# Altium schematic sheet bus-main.SchDoc
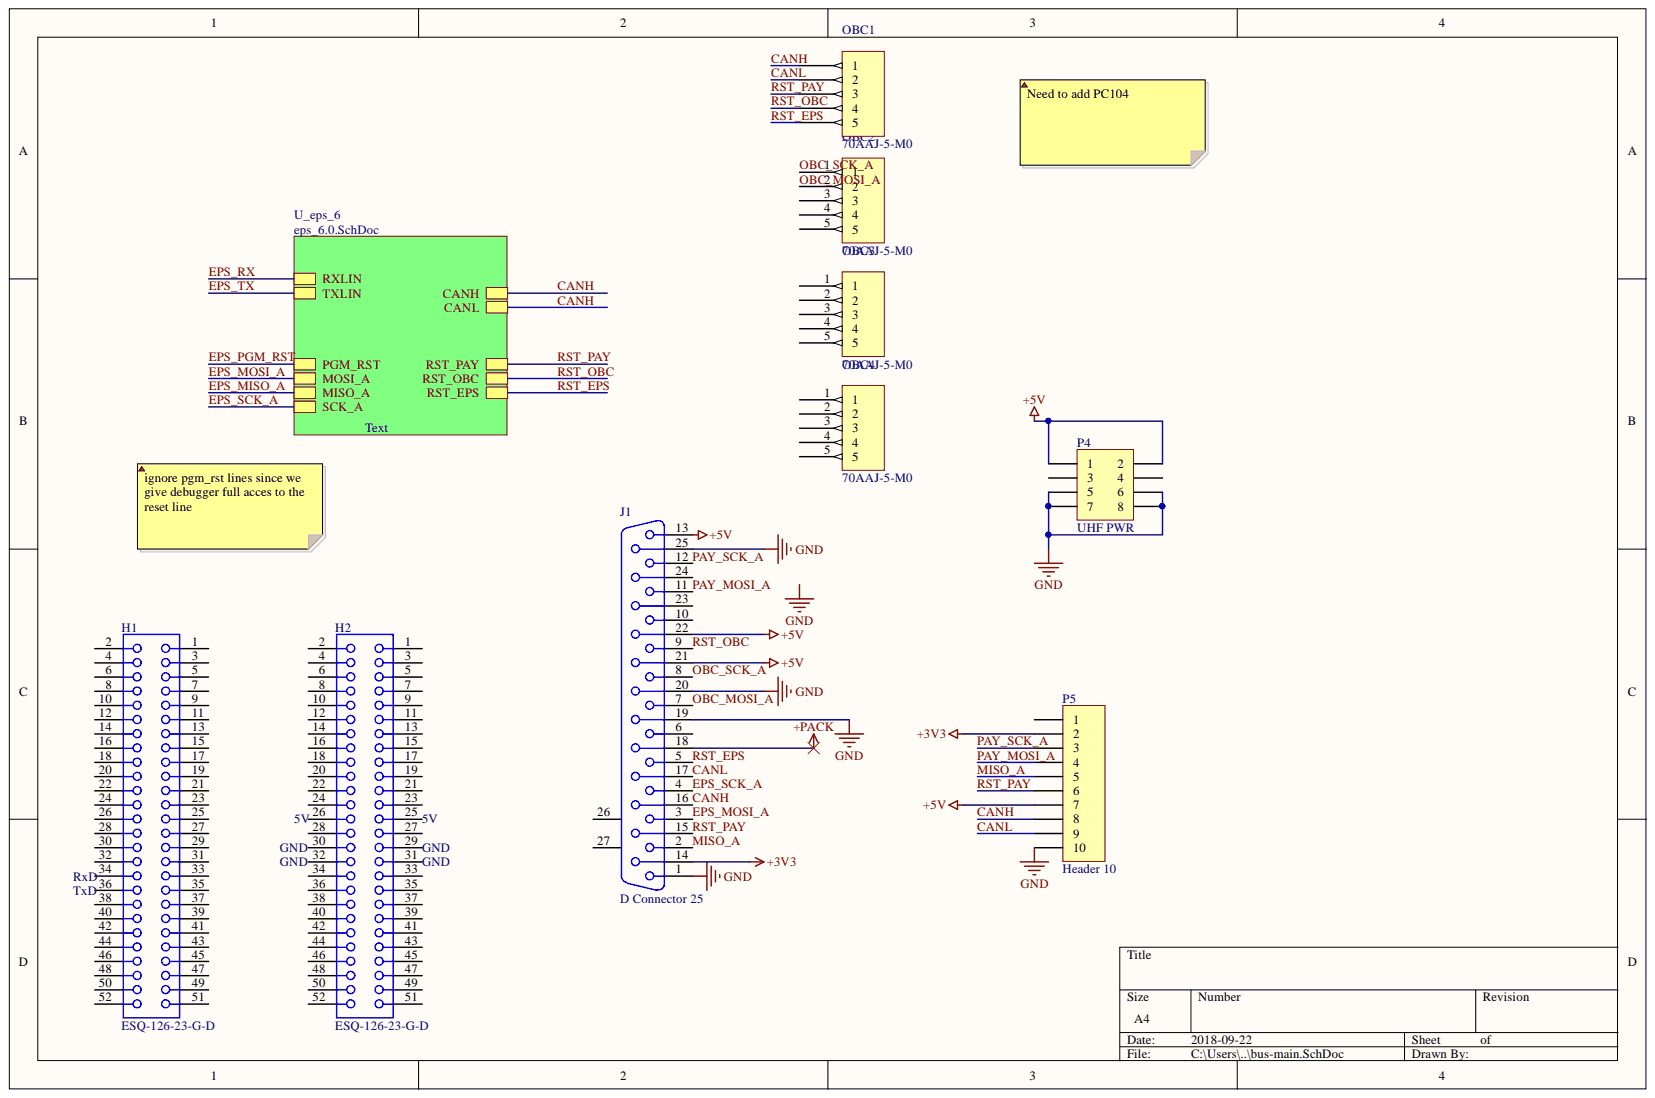
[diagram p0: bus-main.SchDoc]

## Parts
H1  ESQ-126-23-G-D  [SMTC-ESQ-126-23-X-D]
H2  ESQ-126-23-G-D  [SMTC-ESQ-126-23-X-D]
J1  D Connector 25  [Mini DSub Connector]
OBC1  70AAJ-5-M0
OBC2  70AAJ-5-M0
OBC3  70AAJ-5-M0
OBC4  70AAJ-5-M0
P4  UHF PWR  [HDR2X4]
P5  Header 10  [DF14-10P-1.25H(55) - duplicate]

## Nets
+3V3: J1.14 P5.2
+5V: J1.13 J1.21 J1.22 P4.1 P4.2 P5.7
+PACK: J1.18
GND: J1.1 J1.19 J1.20 J1.25 P4.5 P4.6 P4.7 P4.8 P5.10
CANH: J1.16 OBC1.1 P5.8
CANL: J1.17 OBC1.2 P5.9
EPS_MOSI_A: J1.3
EPS_SCK_A: J1.4
MISO_A: J1.2 P5.5
OBC_MOSI_A: J1.7 OBC2.2
OBC_SCK_A: J1.8 OBC2.1
PAY_MOSI_A: J1.11 P5.4
PAY_SCK_A: J1.12 P5.3
RST_EPS: J1.5 OBC1.5
RST_OBC: J1.9 OBC1.4
RST_PAY: J1.15 OBC1.3 P5.6
NetH1_1: H1.1
NetH1_2: H1.2
NetH1_3: H1.3
NetH1_4: H1.4
NetH1_5: H1.5
NetH1_6: H1.6
NetH1_7: H1.7
NetH1_8: H1.8
NetH1_9: H1.9
NetH1_10: H1.10
NetH1_11: H1.11
NetH1_12: H1.12
NetH1_13: H1.13
NetH1_14: H1.14
NetH1_15: H1.15
NetH1_16: H1.16
NetH1_17: H1.17
NetH1_18: H1.18
NetH1_19: H1.19
NetH1_20: H1.20
NetH1_21: H1.21
NetH1_22: H1.22
NetH1_23: H1.23
NetH1_24: H1.24
NetH1_25: H1.25
NetH1_26: H1.26
NetH1_27: H1.27
NetH1_28: H1.28
NetH1_29: H1.29
NetH1_30: H1.30
NetH1_31: H1.31
NetH1_32: H1.32
NetH1_33: H1.33
NetH1_34: H1.34
NetH1_35: H1.35
NetH1_36: H1.36
NetH1_37: H1.37
NetH1_38: H1.38
NetH1_39: H1.39
NetH1_40: H1.40
NetH1_41: H1.41
NetH1_42: H1.42
NetH1_43: H1.43
NetH1_44: H1.44
NetH1_45: H1.45
NetH1_46: H1.46
NetH1_47: H1.47
NetH1_48: H1.48
NetH1_49: H1.49
NetH1_50: H1.50
NetH1_51: H1.51
NetH1_52: H1.52
NetH2_1: H2.1
NetH2_2: H2.2
NetH2_3: H2.3
NetH2_4: H2.4
NetH2_5: H2.5
NetH2_6: H2.6
NetH2_7: H2.7
NetH2_8: H2.8
NetH2_9: H2.9
NetH2_10: H2.10
NetH2_11: H2.11
NetH2_12: H2.12
NetH2_13: H2.13
NetH2_14: H2.14
NetH2_15: H2.15
NetH2_16: H2.16
NetH2_17: H2.17
NetH2_18: H2.18
NetH2_19: H2.19
NetH2_20: H2.20
NetH2_21: H2.21
NetH2_22: H2.22
NetH2_23: H2.23
NetH2_24: H2.24
NetH2_25: H2.25
NetH2_26: H2.26
NetH2_27: H2.27
NetH2_28: H2.28
NetH2_29: H2.29
NetH2_30: H2.30
NetH2_31: H2.31
NetH2_32: H2.32
NetH2_33: H2.33
NetH2_34: H2.34
NetH2_35: H2.35
NetH2_36: H2.36
NetH2_37: H2.37
NetH2_38: H2.38
NetH2_39: H2.39
NetH2_40: H2.40
NetH2_41: H2.41
NetH2_42: H2.42
NetH2_43: H2.43
NetH2_44: H2.44
NetH2_45: H2.45
NetH2_46: H2.46
NetH2_47: H2.47
NetH2_48: H2.48
NetH2_49: H2.49
NetH2_50: H2.50
NetH2_51: H2.51
NetH2_52: H2.52
NetJ1_6: J1.6
NetJ1_10: J1.10
NetJ1_23: J1.23
NetJ1_24: J1.24
NetJ1_26: J1.26
NetJ1_27: J1.27
NetOBC2_3: OBC2.3
NetOBC2_4: OBC2.4
NetOBC2_5: OBC2.5
NetOBC3_1: OBC3.1
NetOBC3_2: OBC3.2
NetOBC3_3: OBC3.3
NetOBC3_4: OBC3.4
NetOBC3_5: OBC3.5
NetOBC4_1: OBC4.1
NetOBC4_2: OBC4.2
NetOBC4_3: OBC4.3
NetOBC4_4: OBC4.4
NetOBC4_5: OBC4.5
NetP4_3: P4.3
NetP4_4: P4.4
NetP5_1: P5.1
EPS_MISO_A:
EPS_PGM_RST:
EPS_RX:
EPS_TX:

## Library data of the parts
H1 H2 = ESQ-126-23-G-D: PC/104(TM) Elevated Socket Strip, Through-hole, Vertical, -55 to 125 degC, 2.54 mm Pitch, 52-Pin, Female, RoHS; Manufacturer: Samtec; Pitch: 2.54 mm; Component Kind: Standard; ComponentLink1Description: Manufacturer URL; ComponentLink1URL: http://www.samtec.com; ComponentLink2Description: Datasheet; ComponentLink2URL: http://www.samtec.com/documents/webfiles/pdf/ESQ_TH.PDF; ComponentLink3Description: Package Specification; ComponentLink3URL: http://www.samtec.com/documents/webfiles/cpdf/ESQ-1XX-XX-X-X-XXX-XX-X-XX-MKT.pdf; DatasheetVersion: F-214; Library Name: CONNs.SchLib; Mounting Technology: Through-hole; Orientation: Vertical; PackageDescription: ESQ Strip ASM; PackageReference: ESQ-126-23-X-D; PackageVersion: BZ, 9/1998; PartNumber: ESQ-126-23-G-D; RoHS: True; Supplier 1: Newark; Supplier Part Number 1: 92P1348; Temperature Range: -55 to 125 degC
J1 = D Connector 25: Receptacle Assembly, 25 Position, Right Angle; PackageDocument: U; Positions: 25; Component Kind: Standard; ComponentLink1Description: Mini-D25 - Molex, LLC 0836149012; ComponentLink1URL: https://www.digikey.ca/product-detail/en/molex-llc/0836149012/WM2144-ND/1867000; DatasheetDocument: 19-Mar-1999; Gender: Receptacle; LatestRevisionDate: 17-Jul-2002; LatestRevisionNote: Re-released for DXP Platform.; Library Name: Miscellaneous Connectors.IntLib; Orientation: Right Angle; PackageReference: 787202; Published: 15-Jun-2001; Signal Integrity: Connector; Publisher: Altium Limited
P4 = Header 4X2: Header, 4-Pin, Dual row; Component Kind: Standard; LatestRevisionDate: 17-Jul-2002; LatestRevisionNote: Re-released for DXP Platform.; Library Name: Miscellaneous Connectors.IntLib; Signal Integrity: Connector; Publisher: Altium Limited
P5 = Header 10: Header, 10-Pin; Component Kind: Standard; LatestRevisionDate: 17-Jul-2002; LatestRevisionNote: Re-released for DXP Platform.; Library Name: Miscellaneous Connectors.IntLib; Signal Integrity: Connector; Publisher: Altium Limited
OBC1-OBC4 = 70AAJ-5-M0: Component Kind: Standard; Library Name: CONNs..SchLib
